# Altium schematic sheet Sheet2.SchDoc
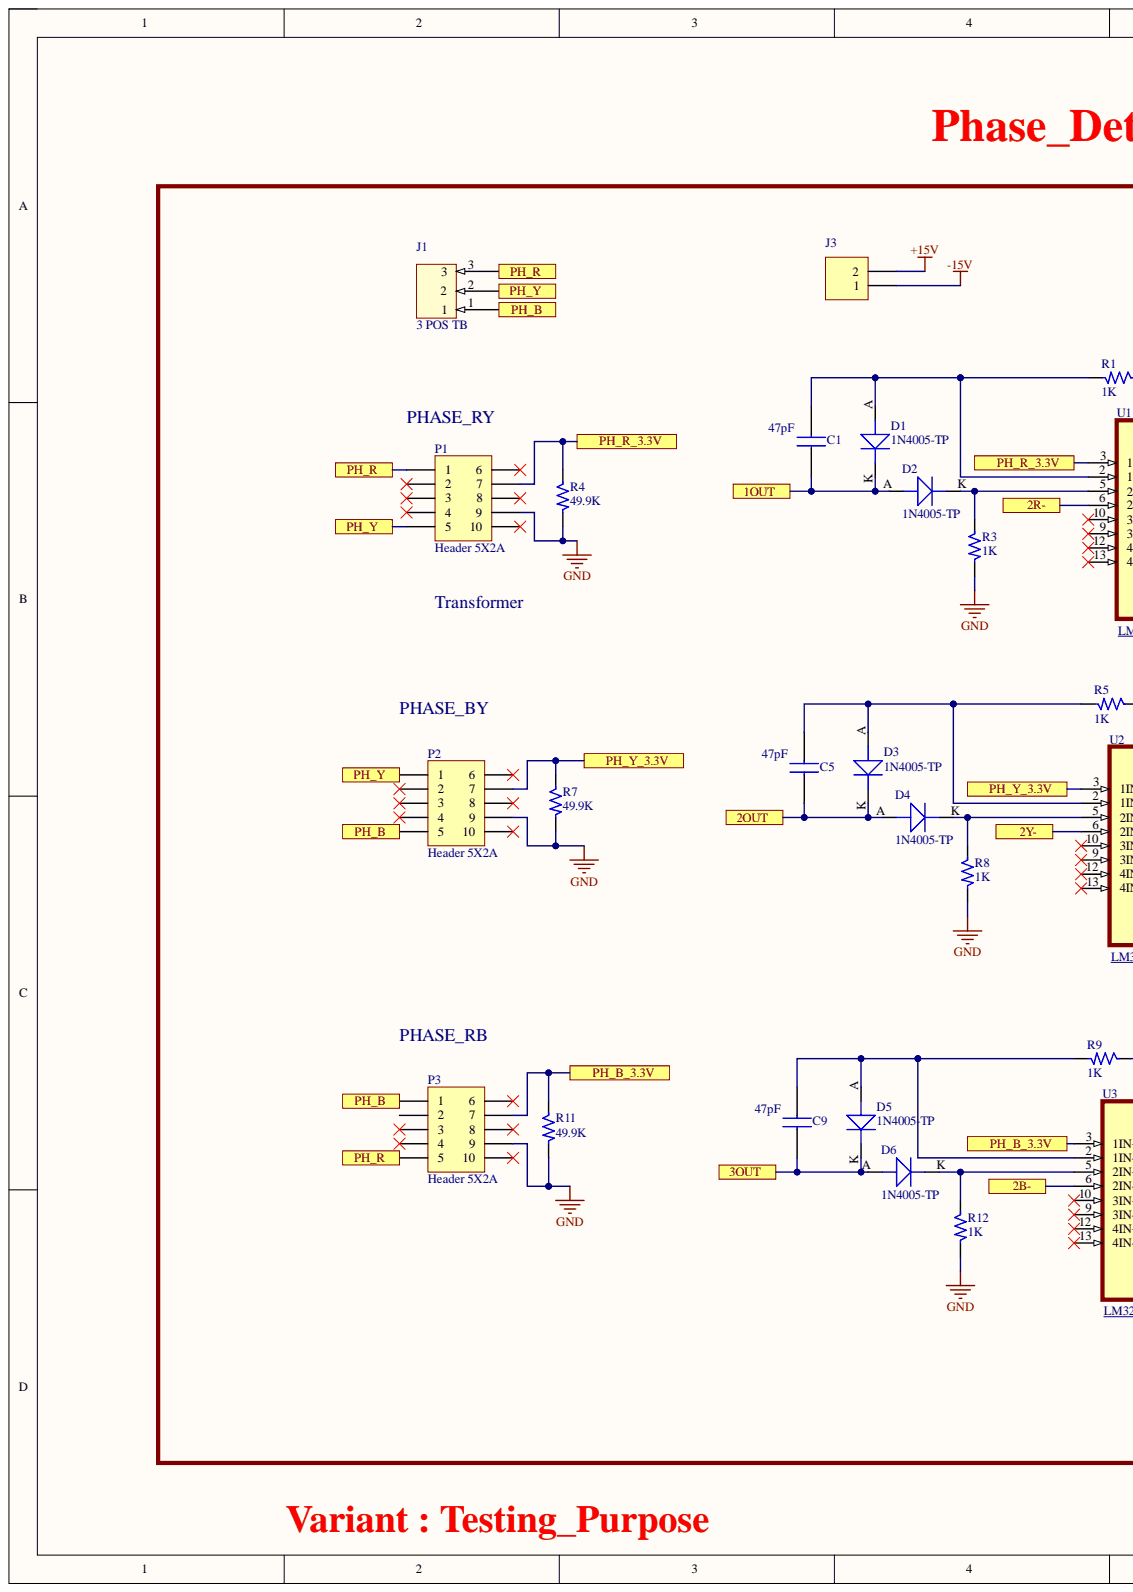
[diagram p0: Sheet2.SchDoc, left]
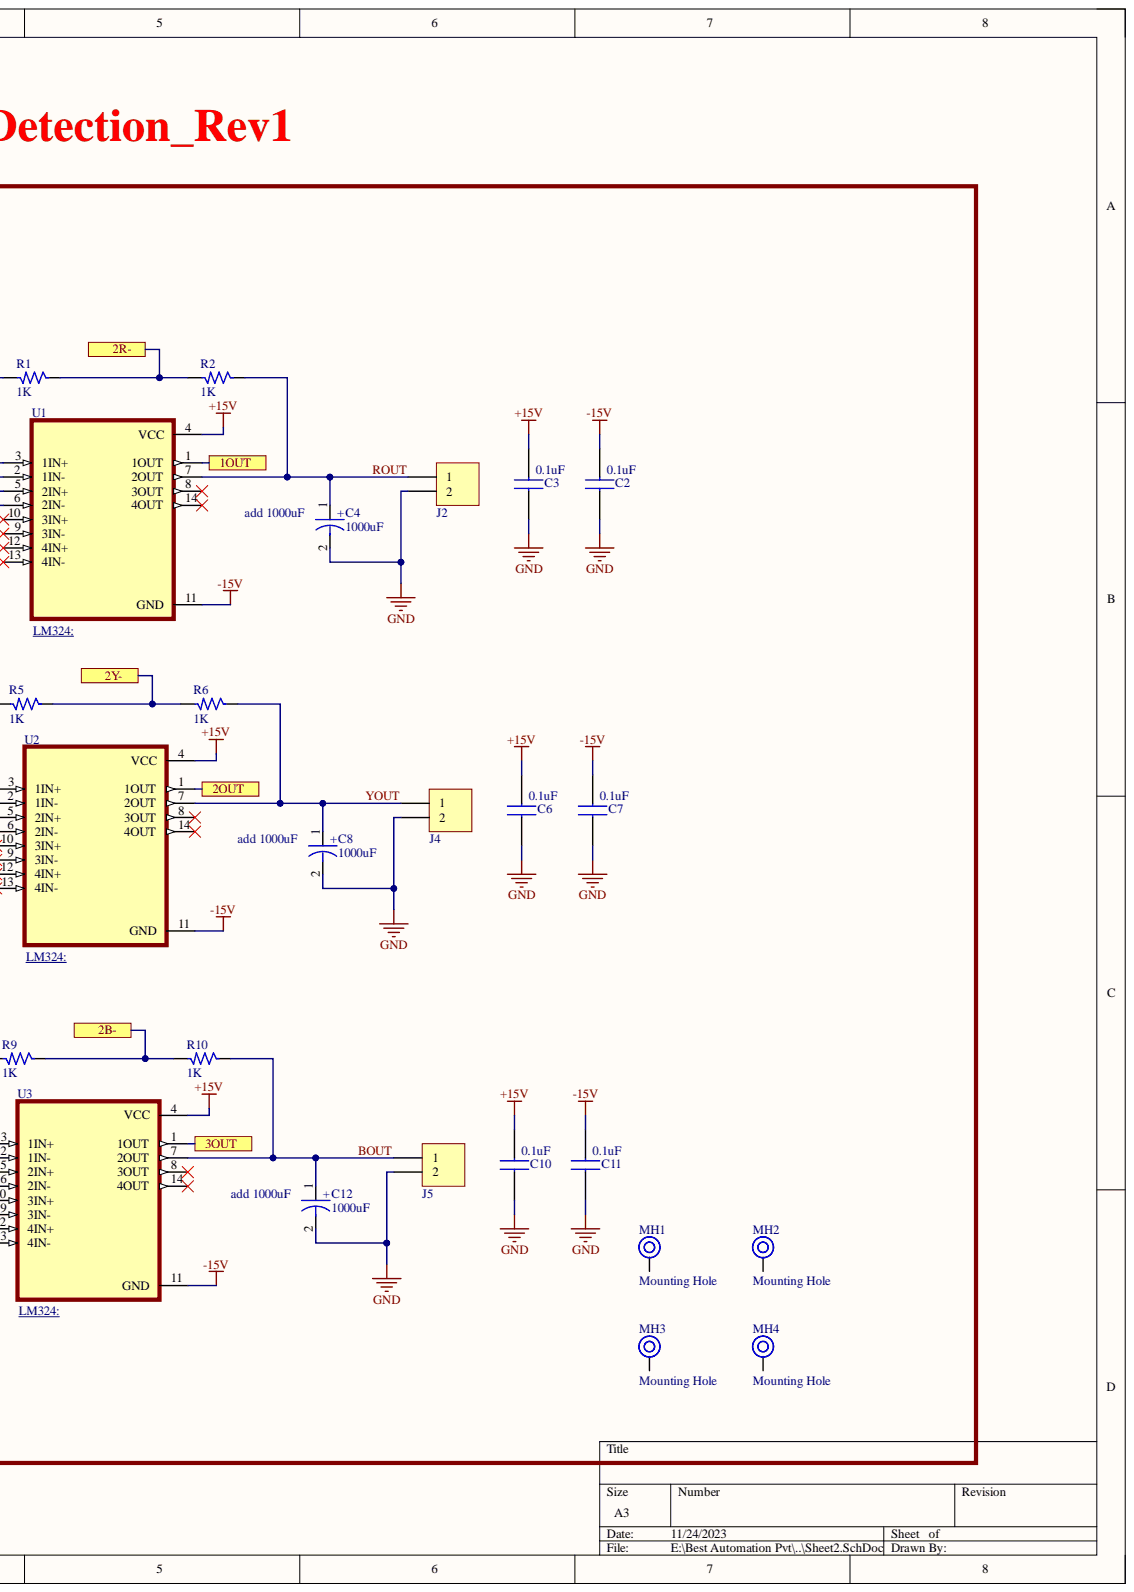
[diagram p1: Sheet2.SchDoc, right]

## Parts
C1  47pF  [CAP_1206]
C2  0.1uF  [CAP_1206]
C3  0.1uF  [CAP_1206]
C4  1000uF  [ECAP_P196_D511_H984_TH]
C5  47pF  [CAP_1206]
C6  0.1uF  [CAP_1206]
C7  0.1uF  [CAP_1206]
C8  1000uF  [ECAP_P196_D511_H984_TH]
C9  47pF  [CAP_1206]
C10  0.1uF  [CAP_1206]
C11  0.1uF  [CAP_1206]
C12  1000uF  [ECAP_P196_D511_H984_TH]
D1  1N4005-TP  [DIO_TO_220_2]
D2  1N4005-TP  [DIO_TO_220_2]
D3  1N4005-TP  [DIO_TO_220_2]
D4  1N4005-TP  [DIO_TO_220_2]
D5  1N4005-TP  [DIO_TO_220_2]
D6  1N4005-TP  [DIO_TO_220_2]
J1  3 POS TB  [CON3_P196_691101710003_TH_ST]
J2  py  [CON2_P196_1729128_TH_ST]  (value not drawn)
J3  py  [CON2_P196_1729128_TH_ST]  (value not drawn)
J4  py  [CON2_P196_1729128_TH_ST]  (value not drawn)
J5  py  [CON2_P196_1729128_TH_ST]  (value not drawn)
MH1  Mounting Hole  [MOUNTING]
MH2  Mounting Hole  [MOUNTING]
MH3  Mounting Hole  [MOUNTING]
MH4  Mounting Hole  [MOUNTING]
P1  Header 5X2A  [Transformer_1]
P2  Header 5X2A  [Transformer_1]
P3  Header 5X2A  [Transformer_1]
R1  1K  [RES_1206]
R2  1K  [RES_1206]
R3  1K  [RES_1206]
R4  49.9K  [RES_539.3_TH]
R5  1K  [RES_1206]
R6  1K  [RES_1206]
R7  49.9K  [RES_539.3_TH]
R8  1K  [RES_1206]
R9  1K  [RES_1206]
R10  1K  [RES_1206]
R11  49.9K  [RES_539.3_TH]
R12  1K  [RES_1206]
U1  (no value)  [DIP794W45P254L1969H508Q14]
U2  (no value)  [DIP794W45P254L1969H508Q14]
U3  (no value)  [DIP794W45P254L1969H508Q14]

## Ports
1OUT, 2B-, 2OUT, 2R-, 2Y-, 3OUT, PH_B, PH_B_3.3V, PH_R, PH_R_3.3V, PH_Y, PH_Y_3.3V

## Nets
+15V: C3.2 C6.2 C10.2 J3.2 U1.4 U2.4 U3.4
-15V: C2.2 C7.2 C11.2 J3.1 U1.11 U2.11 U3.11
GND: C2.1 C3.1 C4.2 C6.1 C7.1 C8.2 C10.1 C11.1 C12.2 J2.2 J4.2 J5.2 P1.9 P2.9 P3.9 R3.2 R4.2 R7.2 R8.2 R11.2 R12.2
1OUT: C1.1 D1.K D2.A U1.1 ; port 1OUT
2B-: R9.1 R10.2 U3.6 ; port 2B-
2OUT: C5.1 D3.K D4.A U2.1 ; port 2OUT
2R-: R1.1 R2.2 U1.6(2IN-) ; port 2R-
2Y-: R5.1 R6.2 U2.6(2IN-) ; port 2Y-
3OUT: C9.1 D5.K D6.A U3.1 ; port 3OUT
BOUT: C12.1 J5.1 R10.1 U3.7
PH_B: J1.1 P2.5 P3.1 ; port PH_B
PH_B_3.3V: P3.7 R11.1 U3.3 ; port PH_B_3.3V
PH_R: J1.3 P1.1 P3.5 ; port PH_R
PH_R_3.3V: P1.7 R4.1 U1.3(1IN+) ; port PH_R_3.3V
PH_Y: J1.2 P1.5 P2.1 ; port PH_Y
PH_Y_3.3V: P2.7 R7.1 U2.3(1IN+) ; port PH_Y_3.3V
ROUT: C4.1 J2.1 R2.1 U1.7
YOUT: C8.1 J4.1 R6.1 U2.7
NetC1_2: C1.2 D1.A R1.2 U1.2(1IN-)
NetC5_2: C5.2 D3.A R5.2 U2.2(1IN-)
NetC9_2: C9.2 D5.A R9.2 U3.2
NetD2_K: D2.K R3.1 U1.5(2IN+)
NetD4_K: D4.K R8.1 U2.5(2IN+)
NetD6_K: D6.K R12.1 U3.5

Not connected: MH1 1; MH2 1; MH3 1; MH4 1; P1 2 3 4 6 8 10; P2 2 3 4 6 8 10; P3 2 3 4 6 8 10; U1 8 9(3IN-) 10(3IN+) 12(4IN+) 13(4IN-) 14; U2 8 9 10 12 13 14; U3 8 9 10 12 13 14

## Library data of the parts
C1-C3 C5-C7 C9-C11 = K104Z15Y5VE5TL2: Vishay K 100nF Ceramic Multilayer Capacitor, 100 V dc, +85C, Y5V Dielectric, +80 %, -20 %; Geometry.Height: 5.6mm; Component Kind: Standard; Datasheet Link: http://www.vishay.com/docs/45171/kseries.pdf; Library Name: Schlib1.SchLib; Manufacturer_Name: Vishay; Manufacturer_Part_Number: K104Z15Y5VE5TL2; Mouser Part Number: 594-K104Z15Y5VE5TL2; Mouser Price/Stock: https://www.mouser.co.uk/ProductDetail/Vishay-BC-Components/K104Z15Y5VE5TL2?qs=dZIvsO2gZWIlp1twxs%2FroQ%3D%3D
C4 C8 C12 = CAP-P_1000uF-50V-20%-TH: 1000µF 50V Aluminum Electrolytic Capacitors Radial, Can 2000 Hrs @ 85°C; Alternate Part Number-1: NRSS102M50V 12.5X25TBF; Manufacturer: Nichicon; Manufacturer Part Number: UVR1H102MHD1TO; Case/Package: Radial-TH; Component Kind: Standard; Library Name: ESTEEMPCBLIB.SchLib; Supplier Part Number-1: UVR1H102MHD1TO; Supplier-1: SP ROAD
D1-D6 = DIO_600V-1A-DO-41: Diode Standard 600V 1A Through Hole DO-41; Alternate Part Number-1: NA; Manufacturer: Vishay Semiconductors; Case/Package: DO-41; Component Kind: Standard; Library Name: precision.SchLib; Manufacturer Part Number: 1N4005-TP; Supplier Part Number-1: 1N4007; Supplier-1: SIRICOM
J1 = CON-TB_3X1-196-ST-TH: 3 Pins, 1 Row 5mm Pitch, straight Connector, male; Alternate Part Number-1: NA; Manufacturer: Wurth Electronics; Manufacturer Part Number: 691101710003; Case/Package: TH-CON; Component Kind: Standard; Library Name: ESTEEMPCBLIB.SchLib; Supplier Part Number-1: 691101710003; Supplier-1: SP ROAD
J2-J5 = CON-TB_2X1-196-ST-TH: 2 Pins, 1 Row 5mm Pitch, straight Connector, male; Alternate Part Number-1: NA; Manufacturer: Phoenix Contact; Manufacturer Part Number: MKDSN1,5/2; Case/Package: TH-CON; Component Kind: Standard; Library Name: ESTEEMPCBLIB.SchLib; Supplier Part Number-1: MKDSN1,5/2; Supplier-1: SP ROAD
MH1-MH4 = MH_3.5H_6D: Alternate Part Number-1: NA; Case/Package: TH; Component Kind: Standard; Description: Mounting Hole; Library Name: ESTEEMPCBLIB.SchLib; Manufacturer: NA; Manufacturer Part Number: NA; Supplier Part Number-1: NA; Supplier-1: NA
P1-P3 = Header 5X2A: Header, 5-Pin, Dual row; Component Kind: Standard; LatestRevisionDate: 17-Jul-2002; LatestRevisionNote: Re-released for DXP Platform.; Library Name: Miscellaneous Connectors.IntLib; Signal Integrity: Connector; Publisher: Altium Limited
R1-R12 = RES_10R-0.25W-5%-1206: 10 Ohms ±5% 0.25W, 1/4W Chip Resistor 1206 (3216 Metric) Automotive AEC-Q200 Thick Film; Alternate Part Number-1: NA; Manufacturer: Yageo; Manufacturer Part Number: RMCF1206JT10R0; Case/Package: 1206; Component Kind: Standard; Supplier Part Number-1: WR12X10R0FTL; Supplier-1: SIRICOM; Library Name: MFDC_rev1.SchLib
U1-U3 = LM324DR: 4-Channel industry standard operational amplifier 14-SOIC 0 to 70; MF: Texas Instruments; Arrow_Electronics-Purchase-URL: https://snapeda.com/shop?store=Arrow+Electronics&id=1818; Availability: Good; Component Kind: Standard; DigiKey-Purchase-URL: https://snapeda.com/shop?store=DigiKey&id=1818; Library Name: ESTEEMPCBLIB.SchLib; Mouser-Purchase-URL: https://snapeda.com/shop?store=Mouser&id=1818; MP: LM324DR; Package: SOIC-14 Texas Instruments; Price: None; SnapEDA_Link: https://www.snapeda.com/parts/LM324DR/Texas%20Instruments/view-part/1818/?ref=snap; Texas_Instruments-Purchase-URL: https://snapeda.com/shop?store=Texas+Instruments&id=1818
